# Altium schematic sheet RPI2.SchDoc
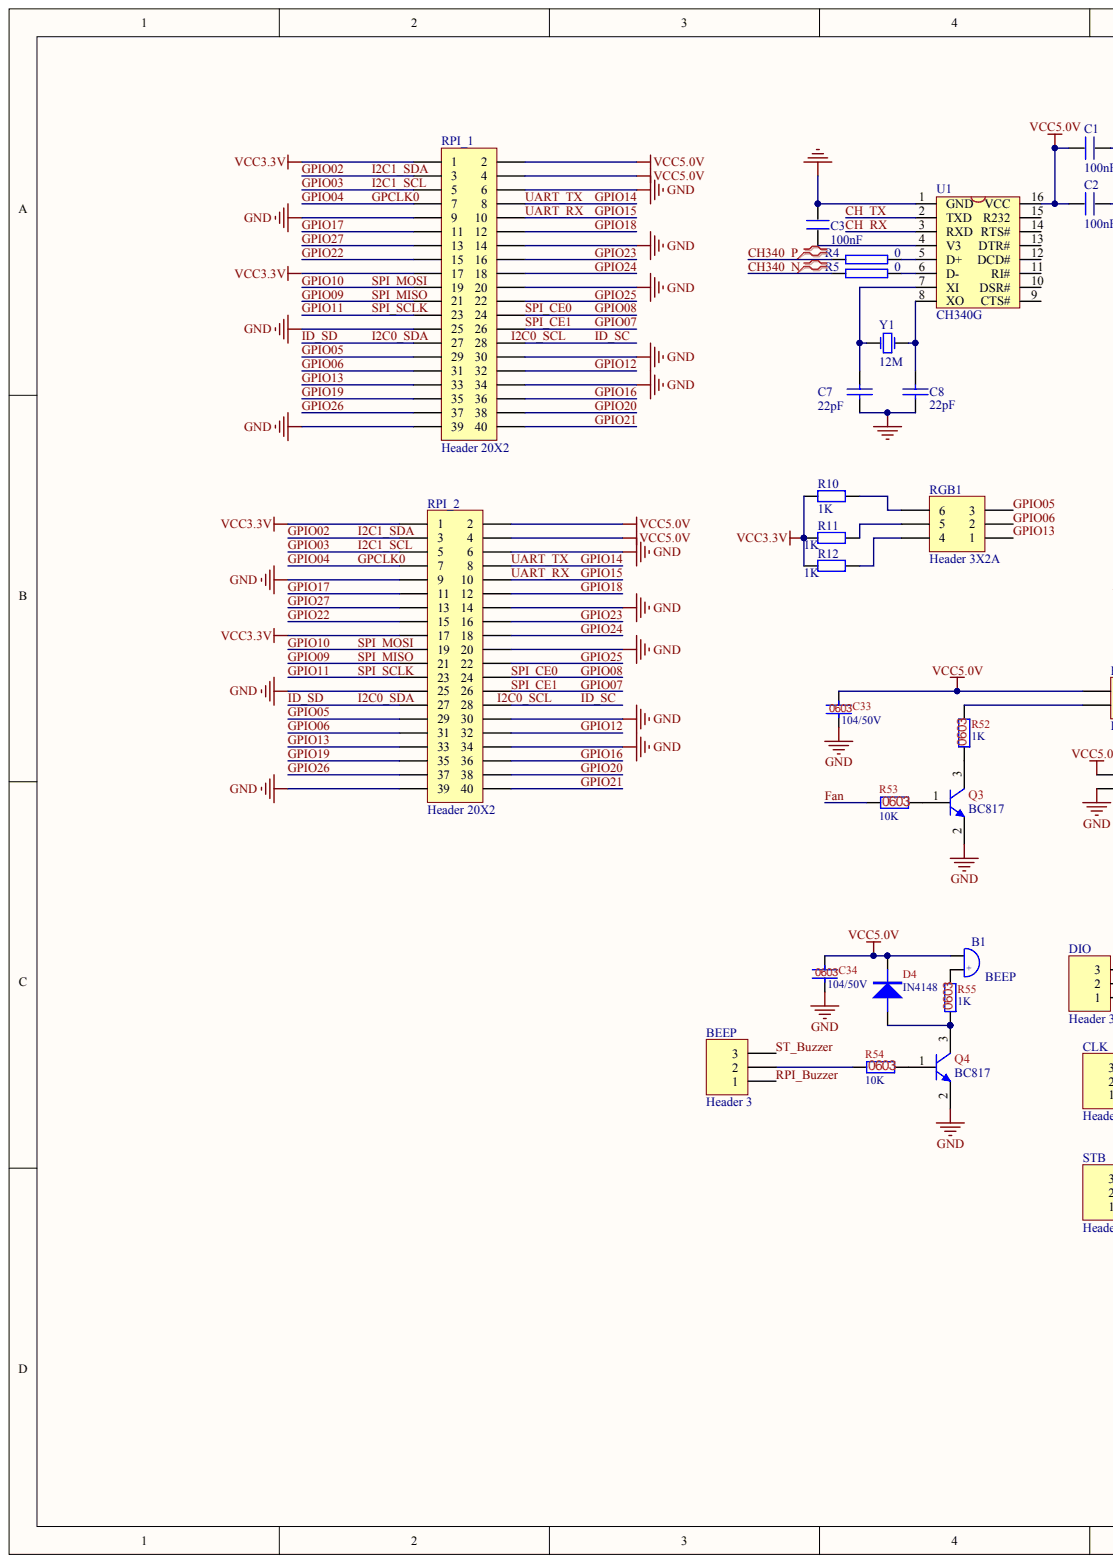
[diagram p0: RPI2.SchDoc, left]
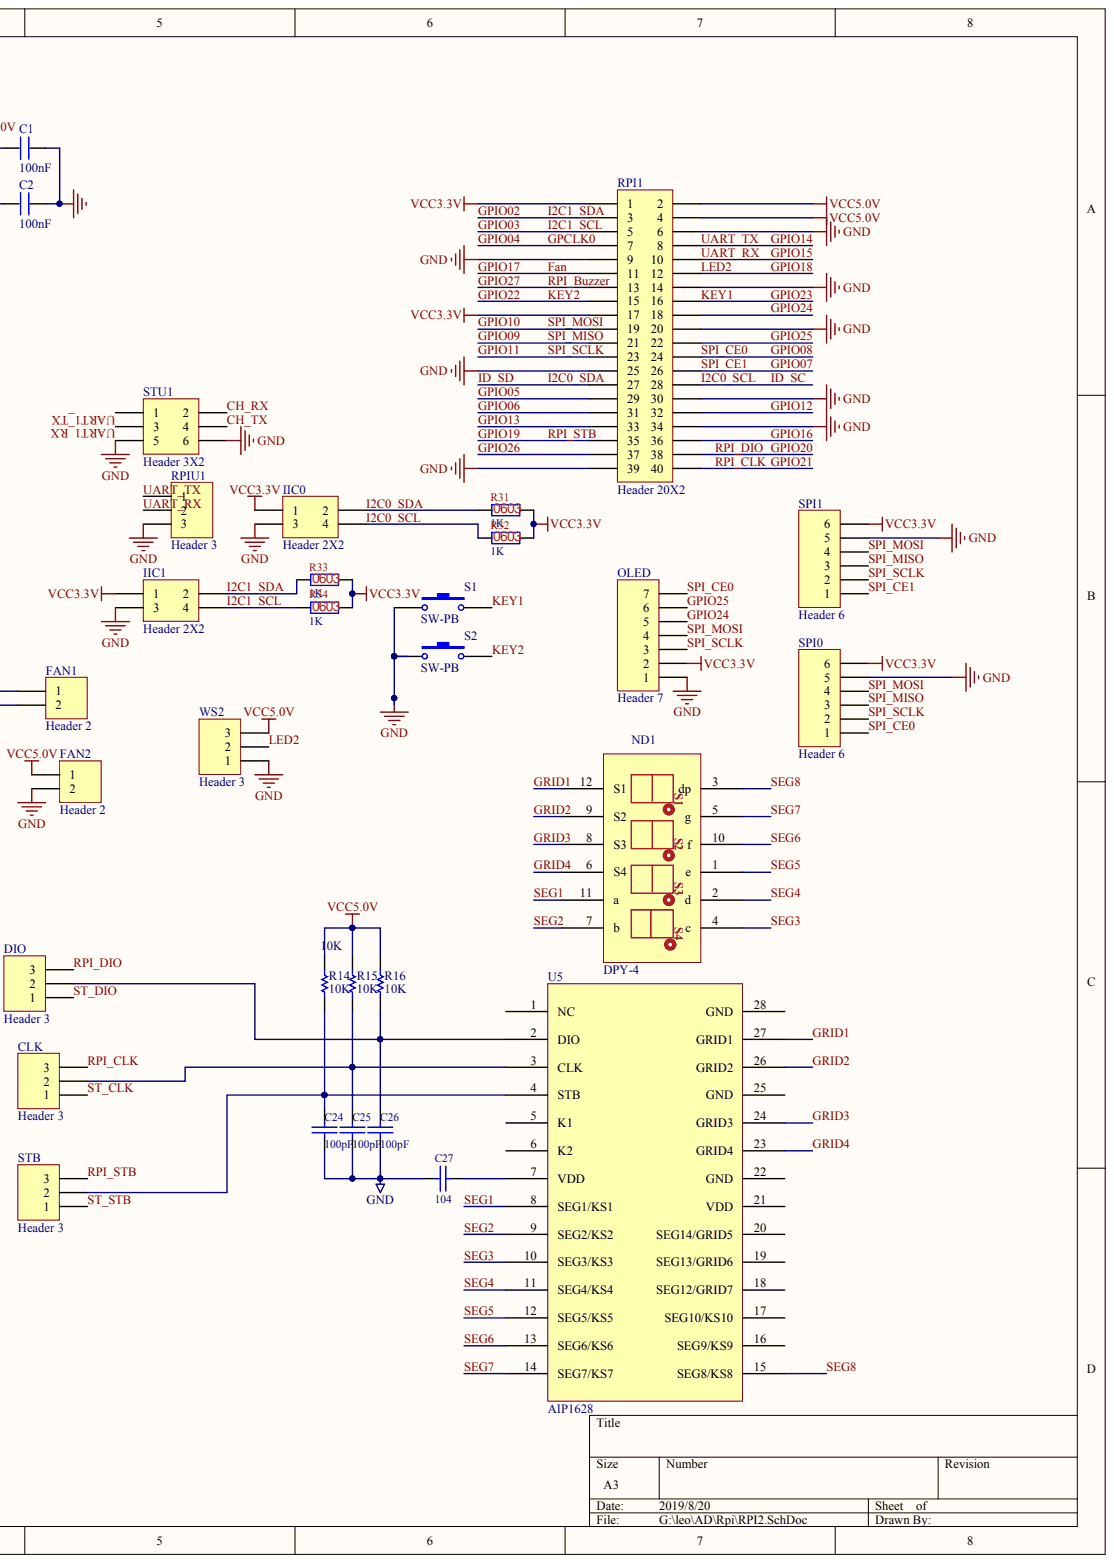
[diagram p1: RPI2.SchDoc, right]

## Parts
B1  BEEP  [BEEP 5x9x5.5]
BEEP  Header 3  [HDR1X3]
C1  100nF  [0603C]
C2  100nF  [0603C]
C3  100nF  [0603C]
C7  22pF  [0603c]
C8  22pF  [0603c]
C24  100pF  [0603C]
C25  100pF  [0603C]
C26  100pF  [0603C]
C27  104  [0805C]
C33  104/50V  [0603C]
C34  104/50V  [0603C]
CLK  Header 3  [HDR1X3]
D4  IN4148  [sod-323]
DIO  Header 3  [HDR1X3]
FAN1  Header 2  [HDR1X2]
FAN2  Header 2  [HDR1X2]
IIC0  Header 2X2  [HDR2X2]
IIC1  Header 2X2  [HDR2X2]
ND1  DPY-4  [LED-4BIT BIG]
OLED  Header 7  [HDR1X7]
Q3  BC817  [SOT-23]
Q4  BC817  [SOT-23]
R4  0  [0603R]
R5  0  [0603R]
R10  1K  [0603R]
R11  1K  [0603R]
R12  1K  [0603R]
R14  10K  [0603R]
R15  10K  [0603R]
R16  10K  [0603R]
R31  1K  [0603R]
R32  1K  [0603R]
R33  1K  [0603R]
R34  1K  [0603R]
R52  1K  [0603R]
R53  10K  [0603R]
R54  10K  [0603R]
R55  1K  [0603R]
RGB1  Header 3X2A  [RGB5050]
RPI1  Header 20X2  [HDR2X20]
RPI_1  Header 20X2  [HDR2X20]
RPI_2  Header 20X2  [HDR2X20]
RPIU1  Header 3  [HDR1X3]
S1  SW-PB  [SW_PB2_A1]
S2  SW-PB  [SW_PB2_A1]
SPI0  Header 6  [HDR1X6]
SPI1  Header 6  [HDR1X6]
STB  Header 3  [HDR1X3]
STU1  Header 3X2  [HDR2X3]
U1  CH340G  [SOP-16_208mil]
U5  AIP1628  [SOP-28]
WS2  Header 3  [HDR1X3]
Y1  12M  [XTAL-HC-49/S]

## Nets
GND: C1.2 C2.2 C3.1 C7.2 C8.2 C24.2 C25.2 C26.2 C27.1 C33.2 C34.2 FAN2.2 IIC0.3 IIC1.3 OLED.1 Q3.2 Q4.2 RPI1.6 RPI1.9 RPI1.14 RPI1.20 RPI1.25 RPI1.30 RPI1.34 RPI1.39 RPI_1.6 RPI_1.9 RPI_1.14 RPI_1.20 RPI_1.25 RPI_1.30 RPI_1.34 RPI_1.39 RPI_2.6 RPI_2.9 RPI_2.14 RPI_2.20 RPI_2.25 RPI_2.30 RPI_2.34 RPI_2.39 RPIU1.3 S1.1 S2.1 SPI0.5 SPI1.5 STU1.5 STU1.6 U1.1(GND) WS2.1
VCC3.3V: IIC0.1 IIC1.1 OLED.2 R10.1 R11.1 R12.1 R31.2 R32.2 R33.2 R34.2 RPI1.1 RPI1.17 RPI_1.1 RPI_1.17 RPI_2.1 RPI_2.17 SPI0.6 SPI1.6
VCC5.0V: B1.2 C1.1 C2.1 C33.1 C34.1 D4.2 FAN1.1 FAN2.1 R14.2 R15.2 R16.2 RPI1.2 RPI1.4 RPI_1.2 RPI_1.4 RPI_2.2 RPI_2.4 U1.16(VCC) WS2.3
CH340_N: R5.2
CH340_P: R4.2
CH_RX: STU1.2 U1.3(RXD)
CH_TX: STU1.4 U1.2(TXD)
FAN: R53.1 RPI1.11 RPI_1.11 RPI_2.11
GPCLK0: RPI1.7 RPI_1.7 RPI_2.7
GPIO02: IIC1.2 R33.1 RPI1.3 RPI_1.3 RPI_2.3
GPIO03: IIC1.4 R34.1 RPI1.5 RPI_1.5 RPI_2.5
GPIO05: RGB1.3 RPI1.29 RPI_1.29 RPI_2.29
GPIO06: RGB1.2 RPI1.31 RPI_1.31 RPI_2.31
GPIO07: RPI1.26 RPI_1.26 RPI_2.26 SPI1.1
GPIO08: OLED.7 RPI1.24 RPI_1.24 RPI_2.24 SPI0.1
GPIO09: RPI1.21 RPI_1.21 RPI_2.21 SPI0.3 SPI1.3
GPIO10: OLED.4 RPI1.19 RPI_1.19 RPI_2.19 SPI0.4 SPI1.4
GPIO11: OLED.3 RPI1.23 RPI_1.23 RPI_2.23 SPI0.2 SPI1.2
GPIO12: RPI1.32 RPI_1.32 RPI_2.32
GPIO13: RGB1.1 RPI1.33 RPI_1.33 RPI_2.33
GPIO14: RPI1.8 RPI_1.8 RPI_2.8 RPIU1.1
GPIO15: RPI1.10 RPI_1.10 RPI_2.10 RPIU1.2
GPIO16: RPI1.36 RPI_1.36 RPI_2.36
GPIO18: RPI1.12 RPI_1.12 RPI_2.12 WS2.2
GPIO19: RPI1.35 RPI_1.35 RPI_2.35 STB.3
GPIO20: DIO.3 RPI1.38 RPI_1.38 RPI_2.38
GPIO21: CLK.3 RPI1.40 RPI_1.40 RPI_2.40
GPIO22: RPI1.15 RPI_1.15 RPI_2.15 S2.2
GPIO23: RPI1.16 RPI_1.16 RPI_2.16 S1.2
GPIO24: OLED.5 RPI1.18 RPI_1.18 RPI_2.18
GPIO25: OLED.6 RPI1.22 RPI_1.22 RPI_2.22
GPIO26: RPI1.37 RPI_1.37 RPI_2.37
GPIO27: BEEP.1 RPI1.13 RPI_1.13 RPI_2.13
GRID1: ND1.12(S1) U5.27(GRID1)
GRID2: ND1.9(S2) U5.26(GRID2)
GRID3: ND1.8(S3) U5.24(GRID3)
GRID4: ND1.6(S4) U5.23(GRID4)
I2C0_SCL: IIC0.4 R32.1 RPI1.28 RPI_1.28 RPI_2.28
I2C0_SDA: IIC0.2 R31.1 RPI1.27 RPI_1.27 RPI_2.27
SEG1: ND1.11(a) U5.8(SEG1/KS1)
SEG2: ND1.7(b) U5.9(SEG2/KS2)
SEG3: ND1.4(S4_c) U5.10(SEG3/KS3)
SEG4: ND1.2(d) U5.11(SEG4/KS4)
SEG5: ND1.1(e) U5.12(SEG5/KS5)
SEG6: ND1.10(S2_f) U5.13(SEG6/KS6)
SEG7: ND1.5(g) U5.14(SEG7/KS7)
SEG8: ND1.3(dp) U5.15(SEG8/KS8)
ST_BUZZER: BEEP.3
ST_CLK: CLK.1
ST_DIO: DIO.1
ST_STB: STB.1
UART1_RX: STU1.3
UART1_TX: STU1.1
NetB1_1: B1.1 R55.2
NetBEEP_2: BEEP.2 R54.1
NetC3_2: C3.2 U1.4(V3)
NetC7_1: C7.1 U1.7(XI) Y1.2
NetC8_1: C8.1 U1.8(XO) Y1.1
NetC24_1: C24.1 R14.1 STB.2 U5.4(STB)
NetC25_1: C25.1 CLK.2 R15.1 U5.3(CLK)
NetC26_1: C26.1 DIO.2 R16.1 U5.2(DIO)
NetC27_2: C27.2 U5.7(VDD)
NetD4_1: D4.1 Q4.3 R55.1
NetFAN1_2: FAN1.2 R52.2
NetQ3_1: Q3.1 R53.2
NetQ3_3: Q3.3 R52.1
NetQ4_1: Q4.1 R54.2
NetR4_1: R4.1 U1.5(D+)
NetR5_1: R5.1 U1.6(D-)
NetR10_2: R10.2 RGB1.6
NetR11_2: R11.2 RGB1.5
NetR12_2: R12.2 RGB1.4

Not connected: U1 9(CTS#) 10(DSR#) 11(RI#) 12(DCD#) 13(DTR#) 14(RTS#) 15(R232); U5 1(NC) 5(K1) 6(K2) 16(SEG9/KS9) 17(SEG10/KS10) 18(SEG12/GRID7) 19(SEG13/GRID6) 20(SEG14/GRID5) 21(VDD) 22(GND) 25(GND) 28(GND)

## Library data of the parts
B1 = BEEP: 蜂鸣器; Component Kind: Standard; Library Name: 蜂鸣器.SchLib
BEEP CLK DIO RPIU1 STB WS2 = Header 3: Header, 3-Pin; Component Kind: Standard; LatestRevisionDate: 17-Jul-2002; LatestRevisionNote: Re-released for DXP Platform.; Library Name: Miscellaneous Connectors.IntLib; Signal Integrity: Connector; Publisher: Altium Limited
C1-C3 = C: Component Kind: Standard
C7 C8 = CAP: 电容; Component Kind: Standard
C24-C27 = CAP: Capacitor; Component Kind: Standard
C33 C34 = 0603C: Component Kind: Standard; Description: 0603C; Library Name: Commonly used libraries.SCHLIB
D4 = Diode: Component Kind: Standard; Description: Diode; Library Name: Commonly used libraries.SCHLIB
FAN1 FAN2 = Header 2: Header, 2-Pin; Component Kind: Standard; LatestRevisionDate: 17-Jul-2002; LatestRevisionNote: Re-released for DXP Platform.; Library Name: Miscellaneous Connectors.IntLib; Signal Integrity: Connector; Publisher: Altium Limited
IIC0 IIC1 = Header 2X2: Header, 2-Pin, Dual row; Component Kind: Standard; LatestRevisionDate: 17-Jul-2002; LatestRevisionNote: Re-released for DXP Platform.; Library Name: Miscellaneous Connectors.IntLib; Signal Integrity: Connector; Publisher: Altium Limited
ND1 = DISPLAY 3641A: Display, 8 x 4; Component Kind: Standard; Library Name: My_Schlib.SchLib
OLED = Header 7: Header, 7-Pin; Component Kind: Standard; LatestRevisionDate: 17-Jul-2002; LatestRevisionNote: Re-released for DXP Platform.; Library Name: Miscellaneous Connectors.IntLib; Signal Integrity: Connector; Publisher: Altium Limited
Q3 Q4 = NPN: NPN Bipolar Transistor; Code_JEDEC: TO-226-AA; Component Kind: Standard; LatestRevisionDate: 17-Jul-2002; LatestRevisionNote: Re-released for DXP Platform.; PackageDescription: TO, Flat Index; 3 In-Line, Axial Leads; Body Dia. 4.8mm; Leads 0.55 x 0.51 mm (max); PackageReference: TO-226-AA; Published: 8-Jun-2000; Signal Integrity: NPN; Simulation: NPN; Library Name: Commonly used libraries.SCHLIB; Publisher: Altium Limited
R4 R5 = RES2: Component Kind: Standard
R10-R12 = Res2: Resistor; Component Kind: Standard; LatestRevisionDate: 17-Jul-2002; LatestRevisionNote: Re-released for DXP Platform.; PackageReference: AXIAL-0.4; Published: 8-Jun-2000; Publisher: Altium Limited
R14-R16 = Res: Resistor; Component Kind: Standard; LatestRevisionDate: 17-Jul-2002; LatestRevisionNote: Re-released for DXP Platform.; Library Name: My_Schlib.SchLib; PackageDescription: Axial Device, Thru-Hole; 2 Leads; 0.3 in Pin Spacing; PackageReference: AXIAL-0.3; Published: 8-Jun-2000; Value: 10K; Publisher: Altium Limited
R31-R34 R52-R55 = 0603R: Component Kind: Standard; Description: 0603R; Library Name: Commonly used libraries.SCHLIB
RGB1 = Header 3X2A: Header, 3-Pin, Dual row; Component Kind: Standard; LatestRevisionDate: 17-Jul-2002; LatestRevisionNote: Re-released for DXP Platform.; Library Name: Miscellaneous Connectors.IntLib; Signal Integrity: Connector; Publisher: Altium Limited
RPI1 RPI_1 RPI_2 = Header 20X2: Header, 20-Pin, Dual row; Component Kind: Standard; LatestRevisionDate: 17-Jul-2002; LatestRevisionNote: Re-released for DXP Platform.; Library Name: Miscellaneous Connectors.IntLib; Signal Integrity: Connector; Publisher: Altium Limited
S1 S2 = SW-PB: 微动开关; Component Kind: Standard; LatestRevisionDate: 17-Jul-2002; LatestRevisionNote: Re-released for DXP Platform.; PackageReference: SPST-2; Published: 24-Mar-1999; Library Name: fszhang.SchLib; Publisher: Altium Limited
SPI0 SPI1 = Header 6: Header, 6-Pin; Component Kind: Standard; LatestRevisionDate: 17-Jul-2002; LatestRevisionNote: Re-released for DXP Platform.; Library Name: Miscellaneous Connectors.IntLib; Signal Integrity: Connector; Publisher: Altium Limited
STU1 = Header 3X2: Header, 3-Pin, Dual row; Component Kind: Standard; LatestRevisionDate: 17-Jul-2002; LatestRevisionNote: Re-released for DXP Platform.; Library Name: Miscellaneous Connectors.IntLib; Signal Integrity: Connector; Publisher: Altium Limited
U1 = CH340G: USB2UART; Component Kind: Standard
U5 = AIP1628: IC, Display controller; Component Kind: Standard; Library Name: My_Schlib.SchLib
Y1 = XTAL: Crystal Oscillator; Component Kind: Standard; Library Name: LZ PcbLib - ALL.SchLib
